# Altium schematic sheet LEDs.SchDoc
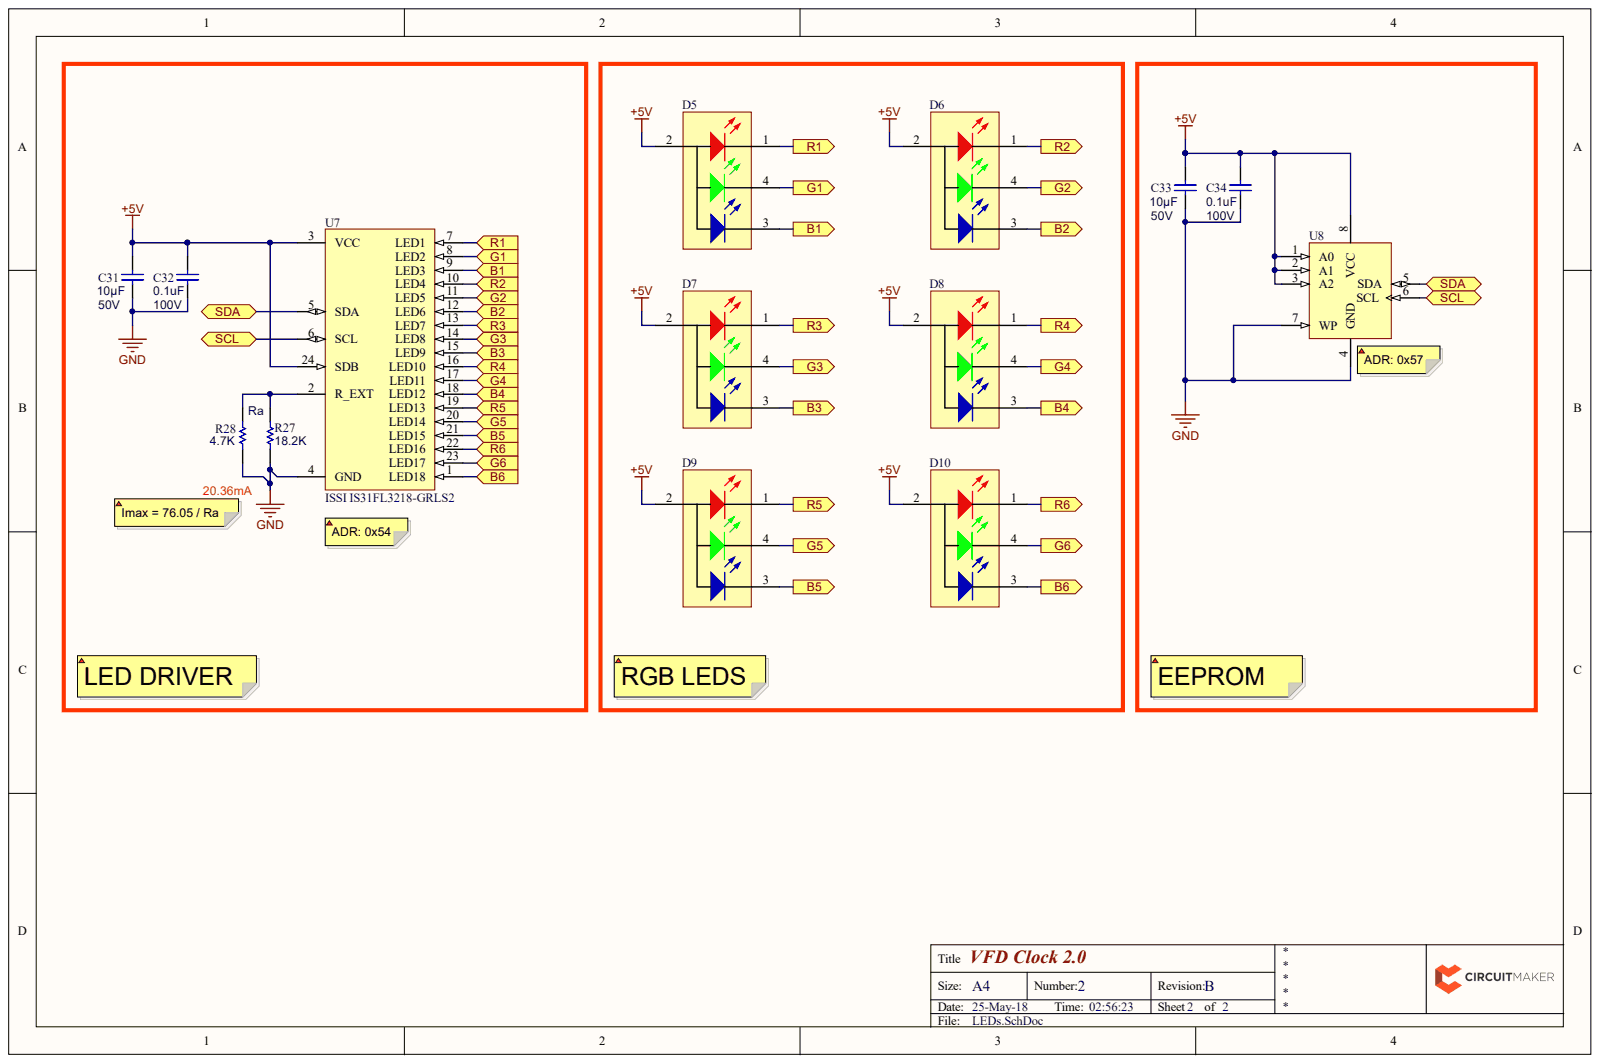
[diagram p0: LEDs.SchDoc]

## Parts
C31  Capacitance: 10µF  Voltage Rating (DC): 50V  [PCB-sxvxwfnl72ysl1kmtzgv-1]  (hidden comment: Samsung CL31A106MBHNNNE)
C32  Capacitance: 0.1uF  Voltage Rating (DC): 100V  [PCB-3l8viaoeo84t9gm70k7i-1]  (hidden comment: Samsung CL21B104KCFNNNE)
C33  Capacitance: 10µF  Voltage Rating (DC): 50V  [PCB-sxvxwfnl72ysl1kmtzgv-1]  (hidden comment: Samsung CL31A106MBHNNNE)
C34  Capacitance: 0.1uF  Voltage Rating (DC): 100V  [PCB-3l8viaoeo84t9gm70k7i-1]  (hidden comment: Samsung CL21B104KCFNNNE)
D5  SunLED XZMDKCBDDG45S-9  [BGA56x10]  (value not drawn)
D6  SunLED XZMDKCBDDG45S-9  [BGA56x10]  (value not drawn)
D7  SunLED XZMDKCBDDG45S-9  [BGA56x10]  (value not drawn)
D8  SunLED XZMDKCBDDG45S-9  [BGA56x10]  (value not drawn)
D9  SunLED XZMDKCBDDG45S-9  [BGA56x10]  (value not drawn)
D10  SunLED XZMDKCBDDG45S-9  [BGA56x10]  (value not drawn)
R27  Resistance: 18.2K  [PCB-jf5k9cyfk2c32myyyjc8-1]  (hidden comment: Yageo RC0603FR-0718K2L)
R28  Resistance: 4.7K  [PCB-jf5k9cyfk2c32myyyjc8-1]  (hidden comment: Yageo RC0603FR-074K7L)
U7  ISSI IS31FL3218-GRLS2  Manufacturer Part Number: IS31FL3218-GRLS2  [SOP24]
U8  STMicroelectronics M24256-BRMN6TP  [D8_N]  (value not drawn)

## Ports
B1, B2, B3, B4, B5, B6, G1, G2, G3, G4, G5, G6, R1, R2, R3, R4, R5, R6, SCL, SDA

## Nets
+5V: C31.2 C32.2 C33.2 C34.2 D5.2 D6.2 D7.2 D8.2 D9.2 D10.2 U7.3(VCC) U7.24(SDB) U8.1(A0) U8.2(A1) U8.3(A2) U8.8(VCC)
GND: C31.1 C32.1 C33.1 C34.1 R27.1 R28.1 U7.4(GND) U8.4(GND) U8.7(WP)
B1: D5.3 U7.9(LED3) ; port B1
B2: D6.3 U7.12(LED6) ; port B2
B3: D7.3 U7.15(LED9) ; port B3
B4: D8.3 U7.18(LED12) ; port B4
B5: D9.3 U7.21(LED15) ; port B5
B6: D10.3 U7.1(LED18) ; port B6
G1: D5.4 U7.8(LED2) ; port G1
G2: D6.4 U7.11(LED5) ; port G2
G3: D7.4 U7.14(LED8) ; port G3
G4: D8.4 U7.17(LED11) ; port G4
G5: D9.4 U7.20(LED14) ; port G5
G6: D10.4 U7.23(LED17) ; port G6
R1: D5.1 U7.7(LED1) ; port R1
R2: D6.1 U7.10(LED4) ; port R2
R3: D7.1 U7.13(LED7) ; port R3
R4: D8.1 U7.16(LED10) ; port R4
R5: D9.1 U7.19(LED13) ; port R5
R6: D10.1 U7.22(LED16) ; port R6
SCL: U7.6(SCL) U8.6(SCL) ; port SCL
SDA: U7.5(SDA) U8.5(SDA) ; port SDA
NetR27_2: R27.2 R28.2 U7.2(R_EXT)

## Library data of the parts
C31 C33 = CMP-32a5ffa1fad6ed62-3: Cap Ceramic 10uF 50V X5R 20% SMD 1206 85°C Embossed T/R; Capacitance Tolerance: ±20%; Case/Package: 1206; Component Kind: Standard; Dielectric Characteristic: X5R; Library Name: CommunityVault; Manufacturer Part Number: CL31A106MBHNNNE; OctopartID: 32a5ffa1fad6ed62; Octopart URL: https://octopart.com/cl31a106mbhnnne-samsung-68087061
C32 C34 = CMP-a5716d0754de7ab6-2: CL21 Series 0.1 uF 100V ±10 % Tolerance X7R SMT Multilayer Ceramic Capacitor; Capacitance Tolerance: ±10%; Lead-Free Status: Lead Free; Case/Package: 0805; Component Kind: Standard; Dielectric Characteristic: X7R; Library Name: CommunityVault; Manufacturer Part Number: CL21B104KCFNNNE; Mounting Style: Surface Mount; OctopartID: a5716d0754de7ab6; Octopart URL: http://octopart.com/cl21b104kcfnnne-samsung-24958153; Packaging: Tape & Reel (TR); RoHS: Compliant
D5-D10 = CMP-3f3af4233e41e0fd-4: PLCC4 Full Color (RGB) LED with Water Clear Flat Lens; Component Kind: Standard; Library Name: CommunityVault; Manufacturer Part Number: XZMDKCBDDG45S-9; Octopart URL: http://octopart.com/xzmdkcbddg45s-9-sunled-34808861
R27 = CMP-161edd6d611ac9d9-2: Res Thick Film 1.6 x 0.8 mm 18.2K Ohm 1% 0.1W(1/10W) 100ppm/ C Molded SMD Paper T/R; Case/Package (SI): 1608; Lead-Free Status: Lead Free; Power Rating: 100 mW; Voltage Rating (DC): 50.0 V; Case/Package: 0603; Component Kind: Standard; Library Name: CommunityVault; Manufacturer Part Number: RC0603FR-0718K2L; Mounting Style: Surface Mount; OctopartID: 161edd6d611ac9d9; Octopart URL: http://octopart.com/rc0603fr-0718k2l-yageo-41505378; Packaging: Reel; Resistance Tolerance: ±1%; RoHS: Compliant; Size-Height: 450 µm; Size-Length: 1.60 mm; Size-Width: 800 µm
R28 = CMP-e2bf28f8a65d3d78-7: Res Thick Film 1.6 x 0.8 mm 4.7K Ohm 1% 0.1W(1/10W) 100ppm/ C Molded SMD Paper T/R; Case/Package (SI): 1608; Lead-Free Status: Lead Free; Power Rating: 100 mW; Voltage Rating (DC): 50.0 V; Case/Package: 0603; Component Kind: Standard; Library Name: CommunityVault; Manufacturer Part Number: RC0603FR-074K7L; Mounting Style: Surface Mount; Number of Pins: 2; OctopartID: e2bf28f8a65d3d78; Octopart URL: http://octopart.com/rc0603fr-074k7l-yageo-55402884; Packaging: Tape & Reel; REACH SVHC Compliance: No SVHC; Resistance Tolerance: ±1%; RoHS: Compliant; Size-Height: 450 µm; Size-Length: 1.60 mm; Size-Width: 800 µm
U7 = CMP-012fc958d788dee8-8: 18-Channel LED Driver, SOP24 (10.0mm x 15.0mm), Tube; Case/Package: 24-SOIC; Component Kind: Standard; Library Name: CommunityVault; Mounting Style: Surface Mount; OctopartID: 012fc958d788dee8; Octopart URL: http://octopart.com/is31fl3218-grls2-issi-25031617; Packaging: Tray; RoHS: Compliant
U8 = CMP-bd2e749d0b6462e9-1: M24256-BR Series 256 kb 5.5 V Surface Mount I2C Serial EEPROM - SOIC-8; Lead-Free Status: Lead Free; Component Kind: Standard; Library Name: CommunityVault; Lifecycle Status: Active; Manufacturer Part Number: M24256-BRMN6TP; Mounting Style: Surface Mount; OctopartID: bd2e749d0b6462e9; Octopart URL: http://octopart.com/m24256-brmn6tp-stmicroelectronics-12161836; Packaging: Tape & Reel (TR); RoHS: Compliant
